# Altium schematic sheet Sheet1.SchDoc(Sheet1)
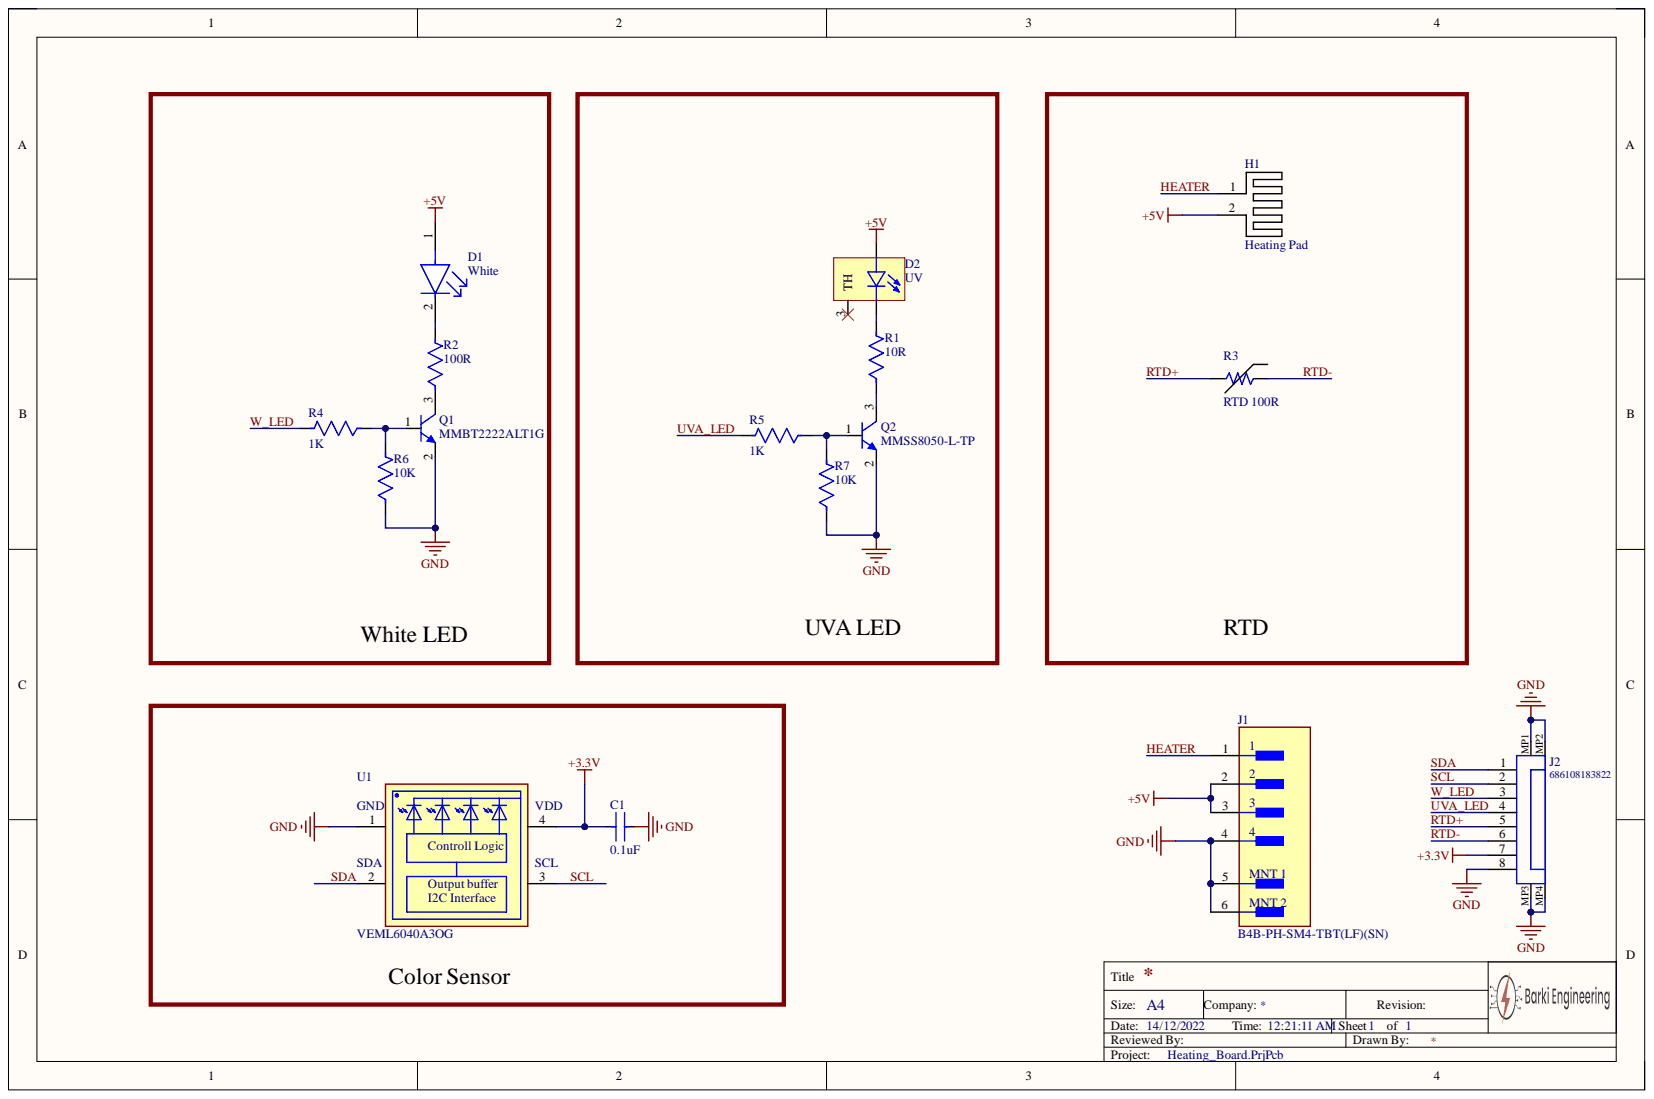
[diagram p0: Sheet1.SchDoc]

## Parts
C1  0.1uF  [C_0603]
D1  White  [LED_2835]
D2  UV  [LED_SST-10-UV-A130-F385-00]
H1  Heating Pad  [Heating Pad]  (no BOM)
J1  B4B-PH-SM4-TBT(LF)(SN)  [FP-B4B-PH-SM4-TBT_LF_SN-MFG]
J2  686108183822  [686108183822]
Q1  MMBT2222ALT1G  [SOT23-3]
Q2  MMSS8050-L-TP  [SOT23-3]
R1  10R  [R_MELF_0207]
R2  100R  [R_0603]
R3  RTD 100R  Resistance: 100R  [0603-RES]
R4  1K  [R_0603]
R5  1K  [R_0603]
R6  10K  [R_0603]
R7  10K  [R_0603]
U1  VEML6040A3OG  [VEML6040]

## Nets
+3.3V: C1.2 J2.7 U1.4
+5V: D1.1 D2.2 H1.2 J1.2 J1.3
GND: C1.1 J1.4 J1.5 J1.6 J2.8 J2.MP1 J2.MP2 J2.MP3 J2.MP4 Q1.2 Q2.2 R6.1 R7.1 U1.1
HEATER: H1.1 J1.1
RTD+: J2.5 R3.2
RTD-: J2.6 R3.1
SCL: J2.2 U1.3
SDA: J2.1 U1.2
UVA_LED: J2.4 R5.2
W_LED: J2.3 R4.2
NetD1_2: D1.2 R2.2
NetD2_1: D2.1 R1.2
NetQ1_1: Q1.1 R4.1 R6.2
NetQ1_3: Q1.3 R2.1
NetQ2_1: Q2.1 R5.1 R7.2
NetQ2_3: Q2.3 R1.1

Not connected: D2 3

## Library data of the parts
C1 = Cap 0.1uF 50V 10% X7R 0603: Ceramic Capacitor 0.1uF 10% X7R 50V 0603 T/R; Manufacturer: Samsung; Manufacturer Part Number: CL10B104KB8WPND; Category: Ceramic Capacitors; Component Kind: Standard; Library Name: OP_Library.SchLib; Pricing 1: 1=0.10000, 10=0.03400, 50=0.03400, 100=0.02600, 1000=0.01500, 10000=0.01100 (USD); RoHS: Yes; RoHS Compliant: Yes; Stock 1: 31698; Supplier 1: Mouser; Supplier Part Number 1: 187-CL10B104KB8WPND
D1 = LED White 3.6V 60mA 2835: SMD 2835 white/warm white; Manufacturer: RZX LED; Manufacturer Part Number: RZXS-2835CW-0.2W-22; Component Kind: Standard; Library Name: OP_Library.SchLib
D2 = LED UV 1A SST-10-UV-A130-F385-00: Ultraviolet (UV) Emitter 385nm  1A  130° 2-SMD, No Lead Exposed Pad; Manufacturer: Luminus Devices; Voltage - Forward (Vf) (Typ): 3.4V; Category: Optoelectronics; Component Kind: Standard; ComponentLink1Description: http://www.luminus.com/products/Luminus_SST-10UV_Datasheet.pdf?src-supplier=Digi-Key; ComponentLink1URL: http://www.luminus.com/products/Luminus_SST-10UV_Datasheet.pdf?src-supplier=Digi-Key; Current - DC Forward (If) (Max): 1A; Family: Infrared, UV, Visible Emitters; Library Name: OP_Library.SchLib; Manufacturer Part Number: SST-10-UV-A130-F385-00; Mounting Type: Surface Mount; Operating Temperature: -; Orientation: Top View; Package / Case: 2-SMD, No Lead, Exposed Pad; Packaging: Tape & Reel (TR); Part Status: Active; Pricing 1: 1=5.87, 10=4.154, 100=3.4022 (USD); Radiant Intensity (Ie) Min @ If: -; Series: SST-10-UV; Stock 1: 1994; Supplier 1: Digi-Key; Supplier Part Number 1: 1214-1421-1-ND; Type: Ultraviolet (UV); Viewing Angle: 130°; Wavelength: 385nm
J1 = CMP-17439-000271-1: CONN HEADER SMD 4POS 2MM; Contact Resistance: 20mR; Mated Stacking Heights: 8.6mm; Max Current Rating: 2A; Max Voltage Rating (AC): 100V; Number of Positions: 4; Color: Natural; Component Kind: Standard; Connector Type: Header; Contact Finish - Mating: TIN; Contact Material: Copper Alloy; Contact Mating Length: 0.13inch; Contact Plating: Copper; Contact Shape: Square; Contact Type: Male Pin; Depth: 5mm; Fastening Type: Detent; Flammability Rating: UL94 V-0; Housing Color: Beige; Housing Material: Polyamide; Insulation Color: Natural; Insulation Material: Nylon; Lead Free: Lead Free; Length: 11.95mm; Library Name: Altium Content Vault; Max Operating Temperature: 85°C; Min Operating Temperature: -25°C; Mount: Surface Mount; Number of Rows: 1; Orientation: Straight; Package Quantity: 1; Packaging: Tape and Reel; Pitch: 2mm; Series: PH; Termination: Solder; Voltage Rating: 100V
J2 = CMP-1502-01503-1: SMT ZIF Connectors WR-FPC, Vertical, Type A, pitch 1 mm, 8 pins; Manufacturer: Wurth Elektronik; Contact Design: TypeA; Contact Resistance: 30mOhm; Pin Number: 8; Pitch: 1mm; Rated Current: 1A; Withstanding Voltage: 250VAC; Working Voltage: 125VAC; Component Kind: Standard; Datasheet URL: https://katalog.we-online.de/em/datasheet/686108183822.pdf; Library Name: Altium Content Vault; Manufacturer URL: http://www.we-online.de; Mounting Technology: SMT; Operating Temperature: -25to85degC; Orientation: Vertical; Package Reference: 686108183822; Packaging: TapeandReel; Part Number: 686108183822; Publisher: WurthElektronik
Q1 = Trans NPN 0.6A 40V SOT23-3 MMBT2222A: Small Signal Bipolar Transistor, 0.6A I(C), 40V V(BR)CEO, 1-Element, NPN, Silicon, TO-236; Manufacturer: ON Semiconductor; DC Current Gain-Min (hFE): 75; Max Collector Current: 600mA; Number of Elements: 1; Package Body Material: Plastic; Power Dissipation-Max (Abs): 300mW; Qualification Status: Not Qualified; Time @ Peak Reflow Temperature-Max (s): 40s; Transistor Application: SWITCHING; Transition Frequency-Nom (fT): 300MHz; Turn-off Time-Max (toff): 285ns; Turn-on Time-Max (ton): 35ns; Category: BJTs; Collector-emitter Voltage-Max: 40V; Component Kind: Standard; ComponentLink1Description: https://datasheet.ciiva.com:443/pdfs/VipMasterIC/IC/ONSM/ONSM-S-A0002809824/ONSM-S-A0002809824-1.pdf?src-supplier=IHS+Markit; ComponentLink1URL: https://datasheet.ciiva.com:443/pdfs/VipMasterIC/IC/ONSM/ONSM-S-A0002809824/ONSM-S-A0002809824-1.pdf?src-supplier=IHS+Markit; ComponentLink2Description: https://datasheet.ciiva.com/16199/mmbt2222lt1-d-16199072.pdf?src-supplier=Future+Electronics; ComponentLink2URL: https://datasheet.ciiva.com/16199/mmbt2222lt1-d-16199072.pdf?src-supplier=Future+Electronics; ComponentLink3Description: https://datasheet.ciiva.com/15139/getdatasheetpartid-82691-15139077.pdf?src-supplier=Verical; ComponentLink3URL: https://datasheet.ciiva.com/15139/getdatasheetpartid-82691-15139077.pdf?src-supplier=Verical; ComponentLink4Description: https://datasheet.ciiva.com/7972/mmbt2222lt1-d-7972057.pdf?src-supplier=Element14; ComponentLink4URL: https://datasheet.ciiva.com/7972/mmbt2222lt1-d-7972057.pdf?src-supplier=Element14; ComponentLink5Description: https://datasheet.ciiva.com/7972/mmbt2222lt1-d-7972058.pdf?src-supplier=Element14; ComponentLink5URL: https://datasheet.ciiva.com/7972/mmbt2222lt1-d-7972058.pdf?src-supplier=Element14; ComponentLink6Description: https://datasheet.ciiva.com/14546/70099528-14546715.pdf?src-supplier=Allied+Electronics; ComponentLink6URL: https://datasheet.ciiva.com/14546/70099528-14546715.pdf?src-supplier=Allied+Electronics; ComponentLink7Description: https://datasheet.ciiva.com/11201/mmbt2222lt1-d-11201869.pdf?src-supplier=Avnet; ComponentLink7URL: https://datasheet.ciiva.com/11201/mmbt2222lt1-d-11201869.pdf?src-supplier=Avnet; ComponentLink8Description: https://datasheet.ciiva.com/976/mmbt2222lt1-d-976345.pdf?src-supplier=Digi-Key; ComponentLink8URL: https://datasheet.ciiva.com/976/mmbt2222lt1-d-976345.pdf?src-supplier=Digi-Key; ComponentLink9Description: https://datasheet.ciiva.com/976/mmbt2222lt1-d-976346.pdf?src-supplier=Digi-Key; ComponentLink9URL: https://datasheet.ciiva.com/976/mmbt2222lt1-d-976346.pdf?src-supplier=Digi-Key; ComponentLink10Description: https://datasheet.ciiva.com/976/mmbt2222lt1-d-976344.pdf?src-supplier=Digi-Key; ComponentLink10URL: https://datasheet.ciiva.com/976/mmbt2222lt1-d-976344.pdf?src-supplier=Digi-Key; JEDEC-95 Code: TO-236; JESD-30 Code: R-PDSO-G3; JESD-609 Code: e3; Library Name: OP_Library.SchLib; Manufacturer Part Number: MMBT2222ALT1G; Max Operating Temperature: 150°C; Moisture Sensitivity Level: 1; Number of Pins: 3; Package Shape: Rectangular; Package Style: SMALL OUTLINEMeter; Peak Reflow Temperature (Cel): 260°C; Polarity/Channel Type: NPN; Pricing 1: 1=0.18000, 10=0.16100, 100=0.08790, 500=0.05410, 1000=0.03689 (USD); REACH SVHC: Yes; RoHS: Yes; RoHS Compliant: Yes; Stock 1: 1075; Supplier 1: Digi-Key; Supplier Part Number 1: MMBT2222ALT1GOSCT-ND; Surface Mount: Yes; Terminal Finish: Tin; Terminal Form: Gull Wing; Terminal Position: DUAL; Transistor Element Material: SILICON
Q2 = Trans NPN 1.2A 25V SOT-23-3 MMSS8050-L-TP: TRANS NPN 25V 1.5A L? SOT-23; Manufacturer: MCC; Collector Base Voltage (VCBO): 40V; Collector Emitter Saturation Voltage: 500mV; Element Configuration: Single; Emitter Base Voltage (VEBO): 5V; Gain Bandwidth Product: 100MHz; hFE Min: 40; Max Power Dissipation: 625mW; Case/Package: TO-236-3; Category: BJTs; Collector Emitter Breakdown Voltage: 25V; Collector Emitter Voltage (VCEO): 500mV; Collector-emitter Voltage-Max: 500mV; Component Kind: Standard; ComponentLink1Description: https://datasheet.ciiva.com/16285/mmss8050sot-23-276387-16285577.pdf?src-supplier=Mouser; ComponentLink1URL: https://datasheet.ciiva.com/16285/mmss8050sot-23-276387-16285577.pdf?src-supplier=Mouser; ComponentLink2Description: https://datasheet.ciiva.com/6362/mmss8050sot-23-6362361.pdf?src-supplier=Digi-Key; ComponentLink2URL: https://datasheet.ciiva.com/6362/mmss8050sot-23-6362361.pdf?src-supplier=Digi-Key; ComponentLink3Description: https://datasheet.ciiva.com/6362/mmss8050sot-23-6362362.pdf?src-supplier=Digi-Key; ComponentLink3URL: https://datasheet.ciiva.com/6362/mmss8050sot-23-6362362.pdf?src-supplier=Digi-Key; ComponentLink4Description: https://datasheet.ciiva.com/6362/mmss8050sot-23-6362363.pdf?src-supplier=Digi-Key; ComponentLink4URL: https://datasheet.ciiva.com/6362/mmss8050sot-23-6362363.pdf?src-supplier=Digi-Key; Lead Free: Lead Free; Library Name: OP_Library.SchLib; Manufacturer Part Number: MMSS8050-L-TP; Max Breakdown Voltage: 25V; Max Collector Current: 1.5A; Max Operating Temperature: 150°C; Min Operating Temperature: -55°C; Mount: Surface Mount; Package Quantity: 3000; Packaging: Tape and Reel; Polarity: NPN; Pricing 1: 1=0.21000, 10=0.17100, 50=0.17100, 100=0.09100, 1000=0.04100, 10000=0.02700 (USD); RoHS: Yes; RoHS Compliant: Yes; Series: -; Stock 1: 93896; Supplier 1: Mouser; Supplier Part Number 1: 833-MMSS8050-L-TP; Transition Frequency: 100MHz
R1 = Res 10R 1% 1W 0207: Res Thin Film 0207(2309) 10 Ohm 1% 1W ±50ppm/C Melf Pad SMD Automotive T/R; Manufacturer: Stackpole Electronics; Manufacturer Part Number: MLFA1FTC10R0; Category: Chip SMD Resistors; Component Kind: Standard; Library Name: OP_Library.SchLib; Pricing 1: 1=0.45000, 10=0.34800, 25=0.26520, 50=0.19700, 100=0.14640, 250=0.12372, 500=0.10604, 1000=0.08080 (USD); RoHS: Yes; RoHS Compliant: Yes; Stock 1: 884; Supplier 1: Digi-Key; Supplier Part Number 1: MLFA1FTC10R0DKR-ND
R2 = Res 100R 1% 1/10W 0603: Fixed Resistor, Thin Film, 0.1W, 100ohm, 75V, 1% +/-Tol, 50ppm/Cel, Surface Mount, 0603; Manufacturer: Yageo; Manufacturer Part Number: RT0603FRE07100RL; Package Height: 0.45mm; Package Length: 1.6mm; Package Width: 0.8mm; Rated Power Dissipation (P): 100mW; Rated Temperature: 70°C; Temperature Coefficient: 50ppm/°C; Category: Chip SMD Resistors; Component Kind: Standard; ComponentLink1Description: https://datasheet.ciiva.com:443/pdfs/VipMasterIC/IC/YAGO/YAGO-S-A0008510989/YAGO-S-A0008510989-1.pdf?src-supplier=IHS+Markit; ComponentLink1URL: https://datasheet.ciiva.com:443/pdfs/VipMasterIC/IC/YAGO/YAGO-S-A0008510989/YAGO-S-A0008510989-1.pdf?src-supplier=IHS+Markit; ComponentLink2Description: https://datasheet.ciiva.com/7746/1392914-7746590.pdf?src-supplier=Element14; ComponentLink2URL: https://datasheet.ciiva.com/7746/1392914-7746590.pdf?src-supplier=Element14; ComponentLink3Description: https://datasheet.ciiva.com/7746/1392914-7746591.pdf?src-supplier=Element14; ComponentLink3URL: https://datasheet.ciiva.com/7746/1392914-7746591.pdf?src-supplier=Element14; ComponentLink4Description: https://datasheet.ciiva.com/12836/pyu-rt-1-to-0-05-rohs-l-4-12836635.pdf?src-supplier=Mouser; ComponentLink4URL: https://datasheet.ciiva.com/12836/pyu-rt-1-to-0-05-rohs-l-4-12836635.pdf?src-supplier=Mouser; ComponentLink5Description: https://datasheet.ciiva.com/2559/pyu-rt-1-to-0-05-rohs-l-5-2559472.pdf?src-supplier=Digi-Key; ComponentLink5URL: https://datasheet.ciiva.com/2559/pyu-rt-1-to-0-05-rohs-l-5-2559472.pdf?src-supplier=Digi-Key; Construction: Chip; Library Name: OP_Library.SchLib; Max Operating Temperature: 155°C; Min Operating Temperature: -55°C; Mount: Surface Mount; Number of Pins: 2; Package Style: SMTMeter; Packaging: Tape and Reel; Pricing 1: 1=0.10000, 10=0.05700, 50=0.05700, 100=0.02300, 1000=0.01100, 2000=0.00900, 5000=0.00800, 10000=0.00800 (USD); REACH SVHC: Yes; Resistance: 100R; Resistor Type: FIXED RESISTOR; RoHS: Yes; RoHS Compliant: Yes; Size Code: 0603; Stock 1: 100591; Supplier 1: Mouser; Supplier Part Number 1: 603-RT0603FRE07100RL; Technology: THIN FILM; Termination: Wraparound; Tolerance: 1%; Working Voltage: 75V
R3 = Res RTD Tem Sensor 100R 0805: Temp Sensor Analog 2-Pin 0805 Paper T/R; Manufacturer: Vishay Beyschlag; Manufacturer Part Number: PTS0805M1B100RP100; Case Code (Imperial): 0805; Case Code (Metric): 2012; Case/Package: 0805; Category: Temperature and Humidity Sensors; Component Kind: Standard; ComponentLink1Description: http://www.mouser.com/ds/2/427/ptsat-710090.pdf?src-supplier=Mouser; ComponentLink1URL: http://www.mouser.com/ds/2/427/ptsat-710090.pdf?src-supplier=Mouser; ComponentLink2Description: http://www.vishay.com/docs/28899/ptsat.pdf?src-supplier=Digi-Key; ComponentLink2URL: http://www.vishay.com/docs/28899/ptsat.pdf?src-supplier=Digi-Key; Library Name: OP_Library.SchLib; Packaging: Tape and Reel; Pricing 1: 1=4.26000, 10=3.32000, 50=2.38000, 100=2.32000, 1000=1.81000, 10000=1.75000 (USD); RoHS: Yes; RoHS Compliant: Yes; Stock 1: 3932; Supplier 1: Mouser; Supplier Part Number 1: 594-PTS0805M1B100RP1
R4 R5 = Res 1K 1% 1/10W 0603: Res Thick Film 0603 1K Ohm 1% 0.1W(1/10W) ±100ppm/C Pad SMD T/R; Manufacturer: Yageo; Manufacturer Part Number: RC0603FR-101KL; Power Rating: 100mW; Resistance: 1kR; Temperature Coefficient: 100ppm/°C; Voltage Rating: 50V; Case Code (Imperial): 0603; Case Code (Metric): 1608; Case/Package: 0603; Category: Chip SMD Resistors; Component Kind: Standard; ComponentLink1Description: https://datasheet.ciiva.com/12560/rc0603-272842-12560633.pdf?src-supplier=Mouser; ComponentLink1URL: https://datasheet.ciiva.com/12560/rc0603-272842-12560633.pdf?src-supplier=Mouser; ComponentLink2Description: https://datasheet.ciiva.com/7983/443971-7983659.pdf?src-supplier=Element14; ComponentLink2URL: https://datasheet.ciiva.com/7983/443971-7983659.pdf?src-supplier=Element14; Construction: Rectangular; Contact Plating: Tin,Lead; Depth: 0.8mm; Height: 0.45mm; Length: 1.6mm; Library Name: OP_Library.SchLib; Max Operating Temperature: 155°C; Military Standard: Not; Min Operating Temperature: -55°C; Number of Pins: 2; Packaging: Tape and Reel; Pricing 1: 1=0.10000, 10=0.01700, 50=0.01700, 100=0.00700, 1000=0.00400, 10000=0.00200 (USD); Radiation Hardening: No; Resistance Tolerance: 1%; Resistor Type: Thick Film; RoHS: Yes; RoHS Compliant: Yes; Series: RC; Stock 1: 105431; Supplier 1: Mouser; Supplier Part Number 1: 603-RC0603FR-101KL; Termination: SMD/SMT; Tolerance: 1%; Voltage Rating (DC): 50V; Width: 0.8mm
R6 R7 = Res 10K 1% 1/5W 0603: Fixed Resistor, Metal Glaze/thick Film, 0.2W, 10000ohm, 75V, 1% +/-Tol, 100ppm/Cel, Surface Mount, 0603; Manufacturer: Yageo; Manufacturer Part Number: AC0603FR-7W10KL; Category: Resistors; Component Kind: Standard; Library Name: OP_Library.SchLib; Pricing 1: 1=0.10000, 10=0.04500, 50=0.04500, 100=0.01900, 1000=0.00900, 10000=0.00500 (USD); RoHS: Yes; Stock 1: 20041; Supplier 1: Mouser; Supplier Part Number 1: 603-AC0603FR-7W10KL
U1 = IC Sensor Color Photo RGB 16-bit: VEML6040 Series 3.6 V 2 x 1.25 x 1 mm RGBW Color Sensor with I2C Interface; Manufacturer: Vishay Semiconductors; Max Supply Current: 200uA; Max Supply Voltage: 3.6V; Min Supply Voltage: 2.5V; Resolution: 16b; Case/Package: TFLGA; Category: Connectors; Component Kind: Standard; ComponentLink1Description: http://www.farnell.com/datasheets/2245071.pdf?src-supplier=Farnell; ComponentLink1URL: http://www.farnell.com/datasheets/2245071.pdf?src-supplier=Farnell; ComponentLink2Description: http://www.farnell.com/datasheets/1898465.pdf?src-supplier=Farnell; ComponentLink2URL: http://www.farnell.com/datasheets/1898465.pdf?src-supplier=Farnell; ComponentLink3Description: http://www.vishay.com/docs/84276/veml6040.pdf?src-supplier=Digi-Key; ComponentLink3URL: http://www.vishay.com/docs/84276/veml6040.pdf?src-supplier=Digi-Key; ComponentLink4Description: http://www.vishay.com/docs/84276/veml6040.pdf?src-supplier=Avnet; ComponentLink4URL: http://www.vishay.com/docs/84276/veml6040.pdf?src-supplier=Avnet; ComponentLink5Description: http://www.farnell.com/datasheets/1898465.pdf?src-supplier=Element14; ComponentLink5URL: http://www.farnell.com/datasheets/1898465.pdf?src-supplier=Element14; ComponentLink6Description: http://www.farnell.com/datasheets/1975875.pdf?src-supplier=Element14; ComponentLink6URL: http://www.farnell.com/datasheets/1975875.pdf?src-supplier=Element14; ComponentLink7Description: http://www.farnell.com/datasheets/2245071.pdf?src-supplier=Newark; ComponentLink7URL: http://www.farnell.com/datasheets/2245071.pdf?src-supplier=Newark; Lead Free: Lead Free; Library Name: OP_Library.SchLib; Manufacturer Part Number: VEML6040A3OG; Max Operating Temperature: 85°C; Min Operating Temperature: -40°C; Number of Pins: 4; Packaging: Cut Tape; Pricing 1: 1=2.58000, 10=1.64000, 50=1.64000, 100=1.40000, 1000=1.12000, 10000=1.12000 (USD); RoHS: Yes; RoHS Compliant: Yes; Series: -; Stock 1: 40482; Supplier 1: Mouser; Supplier Part Number 1: 78-VEML6040A3OG
H1 = Heating Pad: Library Name: OP_Library.SchLib
